# Altium schematic sheet Sheet4.SchDoc(Sheet4)
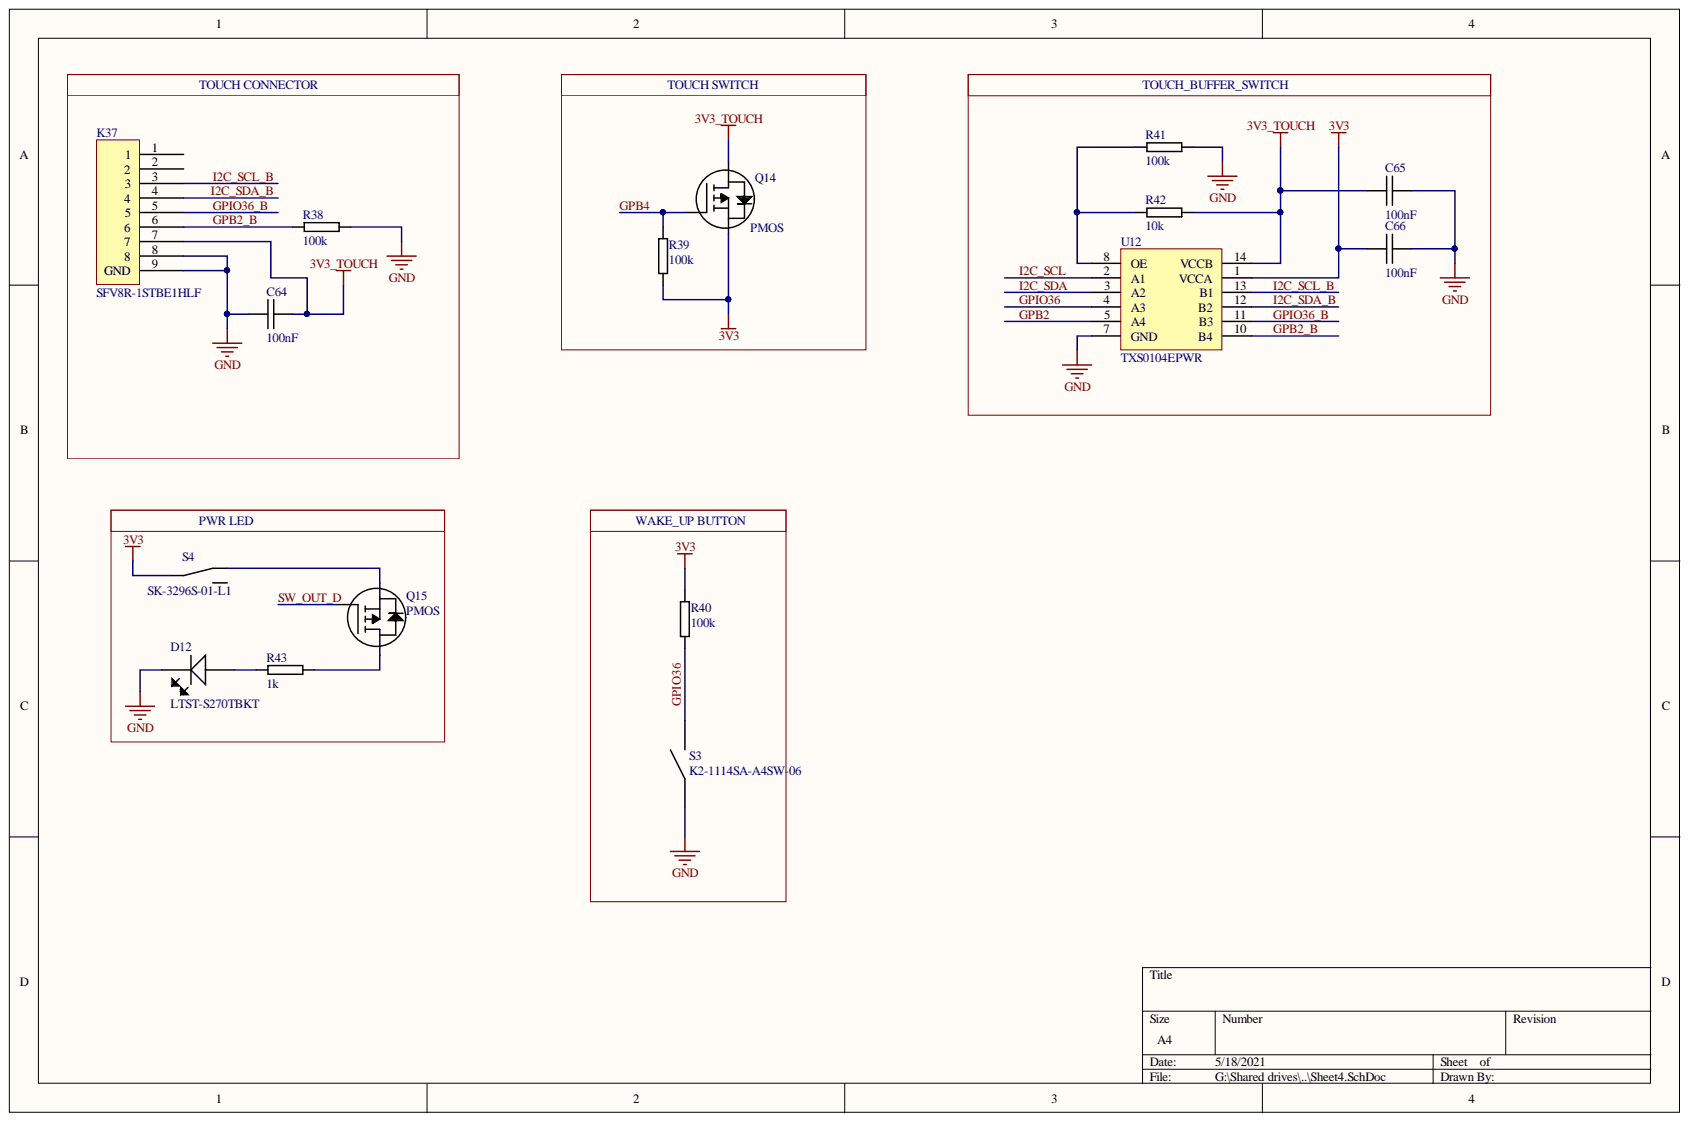
[diagram p0: Sheet4.SchDoc]

## Parts
C64  100nF  [0603C]
C65  100nF  [0603C]
C66  100nF  [0603C]
D12  LTST-S270TBKT  [0603 Side view LED LTST-S270GKT]
K37  SFV8R-1STBE1HLF  [SFV8R-1STBE1HLF]
Q14  PMOS  [SOT-23-3]
Q15  PMOS  [SOT-23-3]
R38  100k  [0603R]
R39  100k  [0603R]
R40  100k  [0603R]
R41  100k  [0603R]
R42  10k  [0603R]
R43  1k  [0603R]
S3  K2-1114SA-A4SW-06  [K2-1114SA-A4SW-06]
S4  SK-3296S-01-L1  [SK-3296S-01-L1]
U12  TXS0104EPWR  [SOP-14]

## Nets
3V3: C66.1 Q14.2 R39.1 R40.2 S4.2 U12.1(VCCA)
3V3_TOUCH: C64.2 C65.1 K37.7 Q14.3 R42.2 U12.14(VCCB)
GND: C64.1 C65.2 C66.2 D12.2 K37.8 K37.9(GND) R38.1 R41.2 S3.1 U12.7(GND)
GPB2: U12.5(A4)
GPB2_B: K37.6 R38.2 U12.10(B4)
GPB4: Q14.1 R39.2
GPIO36: R40.1 S3.2 U12.4(A3)
GPIO36_B: K37.5 U12.11(B3)
I2C_SCL: U12.2(A1)
I2C_SCL_B: K37.3 U12.13(B1)
I2C_SDA: U12.3(A2)
I2C_SDA_B: K37.4 U12.12(B2)
SW_OUT_D: Q15.1
NetD12_1: D12.1 R43.2
NetQ15_2: Q15.2 S4.3
NetQ15_3: Q15.3 R43.1
NetR41_1: R41.1 R42.1 U12.8(OE)

Not connected: K37 1 2; S4 1

## Library data of the parts
C64-C66 = 0603C: Component Kind: Standard; Library Name: e-radionica.com schematics.SchLib
D12 = 0603LED_side view: Component Kind: Standard; Library Name: e-radionica.com schematics.SchLib
K37 = SFV8R-1STBE1HLF: Conn FPC Connector SKT 8 POS 0.5mm Solder RA SMD Embossed T/R; Manufacturer: Amphenol ICC / FCI; Voltage Rating: 50V; Pitch: 0.020" (0.50mm); Number of Positions: 8; Material Flammability Rating: UL94 V-0; Locking Feature: Slide Lock; Flat Flex Type: FFC, FPC; FFC, FCB Thickness: 0.30mm, 0.33mm; Current Rating: 0.5A; Connector/Contact Type: Contacts, Bottom; Cable End Type: Straight, Tapered; Actuator Material: Polyphenylene Sulfide (PPS), Glass Filled, Halogen Free; Termination: Solder; Supplier Part Number 1: 649-SFV8R-1STBE1HLF; Supplier 1: Mouser; Stock 1: 66; Series: SFV-R; Pricing 1: 1=0.41, 10=0.281, 50=0.281, 100=0.232, 1000=0.193, 10000=0.148 (USD); Packaging: Tape & Reel (TR); Operating Temperature: -55°C ~ 105°C; Mounting Type: Surface Mount, Right Angle; Manufacturer Part Number: SFV8R-1STBE1HLF; Housing Material: Polyamide (PA), Nylon, Glass Filled, Halogen Free; Height Above Board: 0.071" (1.80mm); Features: Solder Retention, Zero Insertion Force (ZIF); Family: FFC, FPC (Flat Flexible) Connectors; Contact Material: Phosphor Bronze; Contact Finish: Gold; ComponentLink2URL: http://datasheet.octopart.com/SFV8R-1STBE1HLF-Amphenol-datasheet-94059535.pdf?src-supplier=Element14; ComponentLink2Description: http://datasheet.octopart.com/SFV8R-1STBE1HLF-Amphenol-datasheet-94059535.pdf?src-supplier=Element14; ComponentLink1URL: http://portal.fciconnect.com/Comergent//fci/drawing/sfv12b_hlf.pdf?src-supplier=Digi-Key; ComponentLink1Description: http://portal.fciconnect.com/Comergent//fci/drawing/sfv12b_hlf.pdf?src-supplier=Digi-Key; Component Kind: Standard; Category: Connectors, Interconnects; Library Name: e-radionica.com schematics.SchLib
Q14 Q15 = PMOS SOT-23-3: Supplier Part Number 3: DMP3120L-7; Supplier Part Number 2: 621-DMP3120L-7; Supplier Part Number 1: DMP3120L-7DI-ND; Supplier 3: Arrow; Supplier 2: Mouser; Supplier 1: Digi-Key; Component Kind: Standard; Library Name: e-radionica.com schematics.SchLib
R38-R43 = 0603R: Component Kind: Standard; Library Name: e-radionica.com schematics.SchLib
S3 = Pushbutton K2-1114SA-A4SW-06: Inkplate; Component Kind: Standard; Library Name: e-radionica.com schematics.SchLib
S4 = Switch SK-3296S-01-L1: Component Kind: Standard; Library Name: e-radionica.com schematics.SchLib
U12 = TXB0104QPWRQ1: Component Kind: Standard; Library Name: e-radionica.com schematics.SchLib
